# Altium schematic sheet Cheap_FOCer.SchDoc (continued)

## Library data of the parts
C1 C28 = EEU-FC1C470: CAP, AL, 47 µF, 16 V, +/- 20%, 0.8 ohm, AEC-Q200 Grade 2, TH; Manufacturer: Panasonic; AEC Category: Q200; AEC Grade: 2; AEC Qualified: Y; Cap_Case: 5x11mm; Cap_F: 0.000047; CompType: Capacitor; ESR_ohm: 0.8; Green_Lifecycle: Active; Green_REACh: Passed; IRMS_A: 0.175; SizeArea_mm2: 25; SizeAreaWB_mm2: 49; SizeX_mm: 5; SizeZ_mm: 12; TaMax_C: 105; TaMin_C: -55; Tolerance_%: 20; U/M: EA; Value: 47uF; Value1: 0.8 ohm; Value2: 16V; VDC_V: 16; Component Kind: Standard; ComponentLink1Description: Datasheet link; ComponentLink1URL: http://industrial.panasonic.com/www-data/pdf/ABA0000/ABA0000CE22.pdf; Green_EU RoHS: Passed; Green_EU RoHS-2: Incomplete; LatestRevisionDate: 2017-06-22; Library Name: Capacitor_Aluminum.IntLib; MountType: TH; PackageReference: D5xL11mm; PartNumber: EEU-FC1C470; Polarized: Y; RoHS: Y; Series: FC; Signal Integrity: Cap; Simulation: Cap; SizeY_mm: 5; Supplier 1: Digi-Key; Supplier 2: Newark; Supplier 3: Mouser; Supplier Part Number 1: P11196-ND; Supplier Part Number 2: 17T5082; Supplier Part Number 3: 667-EEU-FC1C470; SymbolVersion: 2008-07-09; Technology: AL; Publisher: Texas Instruments
C2 = EMK212B7225KG-T: CAP, CERM, 2.2 µF, 16 V,+/- 10%, X7R, 0805; Manufacturer: Taiyo Yuden; AEC Category: Q200; Cap_Case: 0805; Cap_F: 0.0000022; CompType: Capacitor; Green_Lifecycle: Active; Green_REACh: Passed; Polarized: N; SizeArea_mm2: 2.5; SizeAreaWB_mm2: 6.75; SizeX_mm: 2; SizeY_mm: 1.25; SizeZ_mm: 1.45; TaMax_C: 125; TaMin_C: -55; Tolerance_%: 10; U/M: EA; Value: 2.2uF; VDC_V: 16; AEC Qualified: ?; Component Kind: Standard; ComponentLink1Description: Datasheet link; ComponentLink1URL: http://www.yuden.co.jp/ut/product/pdf/djmk_e.pdf; Green_EU RoHS: Passed; Green_EU RoHS-2: Passed; LatestRevisionDate: 2017-06-22; Library Name: Capacitor_Ceramic.IntLib; MountType: SMT; PackageReference: 0805; PartNumber: EMK212B7225KG-T; Published: 2008-06-19; RoHS: Y; Signal Integrity: Cap; Simulation: Cap; Supplier 1: Digi-Key; Supplier 2: Farnell; Supplier Part Number 1: 587-1431-1-ND; Supplier Part Number 2: 1683654; SymbolVersion: 2008-07-09; Technology: Ceramic; TempCo: X7R; Publisher: Texas Instruments
C3-C6 = GRM219R71E473KA01D: CAP, CERM, 0.047 µF, 25 V,+/- 10%, X7R, 0805; Manufacturer: MuRata; AEC Category: Q200; Cap_Case: 0805; Cap_F: 0.000000047; CompType: Capacitor; Polarized: N; SizeArea_mm2: 2.5; SizeAreaWB_mm2: 6.75; SizeX_mm: 2; SizeY_mm: 1.25; SizeZ_mm: 1.45; TaMax_C: 125; TaMin_C: -55; Tolerance_%: 10; U/M: EA; Value: 0.047uF; Value2: 25V; VDC_V: 25; AEC Qualified: ?; Component Kind: Standard; ComponentLink1Description: Datasheet link; ComponentLink1URL: http://search.murata.co.jp/Ceramy/PnsearchViewAction.do?HnOFG=ON&HnNFG=ON&SEL_CNT=50&sLang=en&sKey=GRM219R71E473KA01D&x=9&y=9&search=startwith; LatestRevisionDate: 2017-06-22; Library Name: Capacitor_Ceramic.IntLib; MountType: SMT; PackageReference: 0805; PartNumber: GRM219R71E473KA01D; Published: 2008-06-19; RoHS: Y; Signal Integrity: Cap; Simulation: Cap; Supplier 1: Mouser; Supplier Part Number 1: 81-GRM40X473K25D; SymbolVersion: 2008-07-09; Technology: Ceramic; TempCo: X7R; Publisher: Texas Instruments
C7 C12 C15 C21 C25 = C0805C104K4RACTU: CAP, CERM, 0.1 µF, 16 V,+/- 10%, X7R, 0805; Manufacturer: Kemet; AEC Category: Q200; Cap_Case: 0805; Cap_F: 0.0000001; CompType: Capacitor; ESR_ohm: 0.064; Green_Lifecycle: Active; Green_REACh: Passed; IRMS_A: 1.64; Polarized: N; SizeArea_mm2: 2.5; SizeAreaWB_mm2: 6.75; SizeX_mm: 2; SizeY_mm: 1.25; SizeZ_mm: 1.45; TaMax_C: 125; TaMin_C: -55; Tolerance_%: 10; U/M: EA; Value: 0.1uF; Value1: 0.064 ohm; Value2: 16V; VDC_V: 16; AEC Qualified: ?; Component Kind: Standard; ComponentLink1Description: Datasheet link; ComponentLink1URL: http://www.kemet.com/kemet/web/homepage/kechome.nsf/file/Partial%20Copy%20-%20Ceramic%20Surface%20Mount%20Capacitors/$file/F3102_CE.pdf; Green_EU RoHS: Passed; Green_EU RoHS-2: Passed; LatestRevisionDate: 2017-06-22; Library Name: Capacitor_Ceramic.IntLib; MountType: SMT; PackageReference: 0805; PartNumber: C0805C104K4RACTU; Published: 2008-06-19; RoHS: Y; Signal Integrity: Cap; Simulation: Cap; Supplier 1: Digi-Key; Supplier 2: Mouser; Supplier 3: Farnell; Supplier Part Number 1: 399-1167-1-ND; Supplier Part Number 2: 80-C0805C104K4R; Supplier Part Number 3: 1414664; SymbolVersion: 2008-07-09; Technology: Ceramic; TempCo: X7R; Publisher: Texas Instruments
C8 C39 C41 C42 = EKMG500ELL4R7ME11D: CAP, AL, 4.7 µF, 50 V, +/- 20%, TH; Manufacturer: Chemi-Con; AEC Category: Q200; AEC Qualified: N; Cap_Case: 5x11mm; Cap_F: 0.0000047; CompType: Capacitor; IRMS_A: 0.03; Polarized: Y; SizeArea_mm2: 25; SizeAreaWB_mm2: 49; SizeX_mm: 5; SizeZ_mm: 12.5; TaMax_C: 105; TaMin_C: -55; Tolerance_%: 20; U/M: EA; Value: 4.7uF; AEC Grade: N/A; Component Kind: Standard; ComponentLink1Description: Datasheet link; ComponentLink1URL: http://www.chemi-con.co.jp/cgi-bin/CAT_DB/SEARCH/cat_db_al.cgi?e=e&j=p&pdfname=kmg; LatestRevisionDate: 2017-06-22; Library Name: Capacitor_Aluminum.IntLib; MountType: TH; PackageReference: D5xL11mm; PartNumber: EKMG500ELL4R7ME11D; RoHS: Y; Series: KMG; Signal Integrity: Cap; Simulation: Cap; SizeY_mm: 5; Supplier 1: Digi-Key; Supplier Part Number 1: 565-1335-ND; SymbolVersion: 2008-07-09; Technology: AL; Publisher: Texas Instruments
C9 = EKMG500ELL4R7ME11D: CAP, AL, 4.7 µF, 50 V, +/- 20%, TH; Manufacturer: Chemi-Con; AEC Category: Q200; AEC Qualified: N; Cap_Case: 5x11mm; Cap_F: 0.0000047; CompType: Capacitor; IRMS_A: 0.03; Polarized: Y; SizeArea_mm2: 25; SizeAreaWB_mm2: 49; SizeX_mm: 5; SizeZ_mm: 12.5; TaMax_C: 105; TaMin_C: -55; Tolerance_%: 20; U/M: EA; Value: 4.7uF; VDC_V: 50; AEC Grade: N/A; Component Kind: Standard; ComponentLink1Description: Datasheet link; ComponentLink1URL: http://www.chemi-con.co.jp/cgi-bin/CAT_DB/SEARCH/cat_db_al.cgi?e=e&j=p&pdfname=kmg; LatestRevisionDate: 2017-06-22; Library Name: Capacitor_Aluminum.IntLib; MountType: TH; PackageReference: D5xL11mm; PartNumber: EKMG500ELL4R7ME11D; RoHS: Y; Series: KMG; Signal Integrity: Cap; Simulation: Cap; SizeY_mm: 5; Supplier 1: Digi-Key; Supplier Part Number 1: 565-1335-ND; SymbolVersion: 2008-07-09; Technology: AL; Publisher: Texas Instruments
C10 C11 C14 C22 C26 C27 C31-C34 C36 C38 C40 C46 = EMK212B7225KG-T: CAP, CERM, 2.2 µF, 16 V,+/- 10%, X7R, 0805; Manufacturer: Taiyo Yuden; AEC Category: Q200; Cap_Case: 0805; Cap_F: 0.0000022; CompType: Capacitor; Green_Lifecycle: Active; Green_REACh: Passed; Polarized: N; SizeArea_mm2: 2.5; SizeAreaWB_mm2: 6.75; SizeX_mm: 2; SizeY_mm: 1.25; SizeZ_mm: 1.45; TaMax_C: 125; TaMin_C: -55; Tolerance_%: 10; U/M: EA; Value: 2.2uF; Value2: 16V; VDC_V: 16; AEC Qualified: ?; Component Kind: Standard; ComponentLink1Description: Datasheet link; ComponentLink1URL: http://www.yuden.co.jp/ut/product/pdf/djmk_e.pdf; Green_EU RoHS: Passed; Green_EU RoHS-2: Passed; LatestRevisionDate: 2017-06-22; Library Name: Capacitor_Ceramic.IntLib; MountType: SMT; PackageReference: 0805; PartNumber: EMK212B7225KG-T; Published: 2008-06-19; RoHS: Y; Signal Integrity: Cap; Simulation: Cap; Supplier 1: Digi-Key; Supplier 2: Farnell; Supplier Part Number 1: 587-1431-1-ND; Supplier Part Number 2: 1683654; SymbolVersion: 2008-07-09; Technology: Ceramic; TempCo: X7R; Publisher: Texas Instruments
C13 = 08055C223KAT2A: CAP, CERM, 0.022 µF, 50 V,+/- 10%, X7R, 0805; Manufacturer: AVX; AEC Category: Q200; AEC Qualified: N; Cap_Case: 0805; Cap_F: 0.000000022; CompType: Capacitor; ESR_ohm: 0.052; Green_Lifecycle: Not Set; Green_REACh: Incomplete; SizeArea_mm2: 2.5; SizeAreaWB_mm2: 6.75; SizeX_mm: 2; SizeY_mm: 1.25; SizeZ_mm: 1.45; TaMax_C: 125; TaMin_C: -55; Tolerance_%: 10; U/M: EA; Value: 0.022uF; Value1: 0.052 ohm; Value2: 50V; VDC_V: 50; AEC Grade: N/A; Component Kind: Standard; ComponentLink1Description: Datasheet link; ComponentLink1URL: http://datasheets.avx.com/X7RDielectric.pdf; Green_EU RoHS: Incomplete; Green_EU RoHS-2: Incomplete; LatestRevisionDate: 2017-06-22; Library Name: Capacitor_Ceramic.IntLib; MountType: SMT; PackageReference: 0805; PartNumber: 08055C223KAT2A; Polarized: N; Published: 2008-06-19; RoHS: Y; Signal Integrity: Cap; Simulation: Cap; Supplier 1: Digi-Key; Supplier 2: Newark; Supplier 3: Mouser; Supplier Part Number 1: 478-1387-1-ND; Supplier Part Number 2: 35K2132; Supplier Part Number 3: 581-08055C223K; SymbolVersion: 2008-07-09; Technology: Ceramic; TempCo: X7R; Publisher: Texas Instruments
C16 C18 C20 = GRM21BR71H224KA01L: CAP, CERM, 0.22 µF, 50 V,+/- 10%, X7R, 0805; Manufacturer: MuRata; AEC Category: Q200; Cap_Case: 0805; Cap_F: 0.00000022; CompType: Capacitor; Green_Lifecycle: Active; Green_REACh: Passed; Polarized: N; SizeArea_mm2: 2.5; SizeAreaWB_mm2: 6.75; SizeX_mm: 2; SizeY_mm: 1.25; SizeZ_mm: 1.45; TaMax_C: 125; TaMin_C: -55; Tolerance_%: 10; U/M: EA; Value: 0.22uF; Value2: 50V; VDC_V: 50; AEC Qualified: ?; Component Kind: Standard; ComponentLink1Description: Datasheet link; ComponentLink1URL: http://search.murata.co.jp/Ceramy/PnsearchViewAction.do?HnOFG=ON&HnNFG=ON&SEL_CNT=50&sLang=en&sKey=GRM21BR71H224KA01L&x=9&y=9&search=startwith; Green_EU RoHS: Passed; Green_EU RoHS-2: Incomplete; LatestRevisionDate: 2017-06-22; Library Name: Capacitor_Ceramic.IntLib; MountType: SMT; PackageReference: 0805; PartNumber: GRM21BR71H224KA01L; Published: 2008-06-19; RoHS: Y; Signal Integrity: Cap; Simulation: Cap; Supplier 1: Digi-Key; Supplier 2: Mouser; Supplier 3: Farnell; Supplier Part Number 1: 490-1670-1-ND; Supplier Part Number 2: 81-GRM40X7R224K050AL; Supplier Part Number 3: 8820139; SymbolVersion: 2008-07-09; Technology: Ceramic; TempCo: X7R; Publisher: Texas Instruments
C17 C19 = C0805C150J5GACTU: CAP, CERM, 15 pF, 50 V,+/- 5%, C0G/NP0, 0805; Manufacturer: Kemet; AEC Category: Q200; Cap_Case: 0805; Cap_F: 0.000000000015; CompType: Capacitor; ESR_ohm: 0.217; Green_Lifecycle: Not Set; Green_REACh: Incomplete; IRMS_A: 0.331; Polarized: N; SizeArea_mm2: 2.5; SizeAreaWB_mm2: 6.75; SizeX_mm: 2; SizeY_mm: 1.25; SizeZ_mm: 1.45; TaMax_C: 125; TaMin_C: -55; Tolerance_%: 5; U/M: EA; Value1: 0.217 ohm; Value2: 50V; VDC_V: 50; AEC Qualified: ?; Component Kind: Standard; ComponentLink1Description: Datasheet link; ComponentLink1URL: http://www.kemet.com/kemet/web/homepage/kechome.nsf/file/Partial%20Copy%20-%20Ceramic%20Surface%20Mount%20Capacitors/$file/F3102_CE.pdf; Green_EU RoHS: Incomplete; Green_EU RoHS-2: Incomplete; LatestRevisionDate: 2017-06-22; Library Name: Capacitor_Ceramic.IntLib; MountType: SMT; PackageReference: 0805; PartNumber: C0805C150J5GACTU; Published: 2008-06-19; RoHS: Y; Signal Integrity: Cap; Simulation: Cap; Supplier 1: Digi-Key; Supplier 2: Newark; Supplier 3: Mouser; Supplier Part Number 1: 399-1111-1-ND; Supplier Part Number 2: 64K2902; Supplier Part Number 3: 80-C0805C150J5G; SymbolVersion: 2008-07-09; Technology: Ceramic; TempCo: C0G/NP0; ValueDisplayed: 15pF; Publisher: Texas Instruments
C23 C37 = B41866C6567M: CAP, AL, 560 µF, 50 V, +/- 20%, 0.05 ohm, AEC-Q200 Grade 1, TH; Manufacturer: TDK; AEC Category: Q200; AEC Grade: 1; AEC Qualified: Y; Cap_Case: 16x20mm; Cap_F: 0.00056; CompType: Capacitor; ESR_ohm: 0.05; IRMS_A: 1.895; SizeArea_mm2: 256; SizeAreaWB_mm2: 324; SizeX_mm: 16; SizeZ_mm: 22; TaMax_C: 125; TaMin_C: -55; Tolerance_%: 20; U/M: EA; Value: 560uF; Value2: 50V; VDC_V: 50; Component Kind: Standard; ComponentLink1Description: Datasheet link; ComponentLink1URL: http://en.tdk.eu/inf/20/30/db/aec_2015/B41866.pdf; LatestRevisionDate: 2017-06-22; Library Name: Capacitor_Aluminum.IntLib; MountType: TH; PackageReference: D16xL20mm; PartNumber: B41866C6567M; Polarized: Y; RoHS: Y; Series: B14866; Signal Integrity: Cap; Simulation: Cap; SizeY_mm: 16; SymbolVersion: 2008-07-09; Technology: AL; Value1: 0.05 ohm; Publisher: Texas Instruments
C24 C29 = 08055A222JAT2A: CAP, CERM, 2200 pF, 50 V,+/- 5%, C0G/NP0, 0805; Manufacturer: AVX; AEC Category: Q200; AEC Qualified: N; Cap_Case: 0805; Cap_F: 0.0000000022; CompType: Capacitor; ESR_ohm: 0.08; Green_Lifecycle: Not Set; Green_REACh: Incomplete; SizeArea_mm2: 2.5; SizeAreaWB_mm2: 6.75; SizeX_mm: 2; SizeY_mm: 1.25; SizeZ_mm: 1.45; TaMax_C: 125; TaMin_C: -55; Tolerance_%: 5; U/M: EA; Value1: 0.08 ohm; Value2: 50V; VDC_V: 50; AEC Grade: N/A; Component Kind: Standard; ComponentLink1Description: Datasheet link; ComponentLink1URL: http://datasheets.avx.com/C0GNP0-Dielectric.pdf; Green_EU RoHS: Incomplete; Green_EU RoHS-2: Incomplete; LatestRevisionDate: 2017-06-22; Library Name: Capacitor_Ceramic.IntLib; MountType: SMT; PackageReference: 0805; PartNumber: 08055A222JAT2A; Polarized: N; Published: 2008-06-19; RoHS: Y; Signal Integrity: Cap; Simulation: Cap; Supplier 1: Digi-Key; Supplier 2: Newark; Supplier 3: Mouser; Supplier Part Number 1: 478-1330-1-ND; Supplier Part Number 2: 32H4914; Supplier Part Number 3: 581-08055A222J; SymbolVersion: 2008-07-09; Technology: Ceramic; TempCo: C0G/NP0; ValueDisplayed: 2200pF; Publisher: Texas Instruments
C30 = GRM2195C1H682FA01D: CAP, CERM, 6800 pF, 50 V,+/- 1%, C0G/NP0, 0805; Manufacturer: MuRata; AEC Category: Q200; AEC Qualified: N; Cap_Case: 0805; Cap_F: 0.0000000068; CompType: Capacitor; SizeArea_mm2: 2.5; SizeAreaWB_mm2: 6.75; SizeX_mm: 2; SizeY_mm: 1.25; SizeZ_mm: 1.45; TaMax_C: 125; TaMin_C: -55; Tolerance_%: 1; U/M: EA; Value2: 50V; VDC_V: 50; AEC Grade: N/A; Component Kind: Standard; ComponentLink1Description: Datasheet link; ComponentLink1URL: http://www.murata.com/~/media/webrenewal/support/library/catalog/products/capacitor/mlcc/c02e.pdf; LatestRevisionDate: 2017-06-22; Library Name: Capacitor_Ceramic.IntLib; MountType: SMT; PackageReference: 0805; PartNumber: GRM2195C1H682FA01D; Polarized: N; Published: 2008-06-19; RoHS: Y; Signal Integrity: Cap; Simulation: Cap; Supplier 1: Digi-Key; Supplier 2: Mouser; Supplier Part Number 1: 490-10742-1-ND; Supplier Part Number 2: 81-GRM2195C1H682FA1D; SymbolVersion: 2008-07-09; Technology: Ceramic; TempCo: C0G/NP0; ValueDisplayed: 6800pF; Publisher: Texas Instruments
C35 = 08055A121JAT2A: CAP, CERM, 120 pF, 50 V,+/- 5%, C0G/NP0, 0805; Manufacturer: AVX; AEC Category: Q200; AEC Qualified: N; Cap_Case: 0805; Cap_F: 0.00000000012; CompType: Capacitor; ESR_ohm: 0.319; Green_Lifecycle: Not Set; Green_REACh: Incomplete; SizeArea_mm2: 2.5; SizeAreaWB_mm2: 6.75; SizeX_mm: 2; SizeY_mm: 1.25; SizeZ_mm: 1.45; TaMax_C: 125; TaMin_C: -55; Tolerance_%: 5; U/M: EA; Value1: 0.319 ohm; Value2: 50V; VDC_V: 50; AEC Grade: N/A; Component Kind: Standard; ComponentLink1Description: Datasheet link; ComponentLink1URL: http://datasheets.avx.com/C0GNP0-Dielectric.pdf; Green_EU RoHS: Incomplete; Green_EU RoHS-2: Incomplete; LatestRevisionDate: 2017-06-22; Library Name: Capacitor_Ceramic.IntLib; MountType: SMT; PackageReference: 0805; PartNumber: 08055A121JAT2A; Polarized: N; Published: 2008-06-19; RoHS: Y; Signal Integrity: Cap; Simulation: Cap; Supplier 1: Digi-Key; Supplier 2: Mouser; Supplier 3: Farnell; Supplier Part Number 1: 478-1317-1-ND; Supplier Part Number 2: 581-08055A121J; Supplier Part Number 3: 1395923; SymbolVersion: 2008-07-09; Technology: Ceramic; TempCo: C0G/NP0; ValueDisplayed: 120pF; Publisher: Texas Instruments
C43-C45 = CMP-0079493-1: CAP, Film, 0.1 µF, 100 V,+/- 2.5%, TH; Manufacturer: WIMA; AEC Category: Q200; AEC Qualified: N; Cap_Case: 7.2x4.5x6mm; Cap_F: 100nF; CompType: Capacitor; SizeArea_mm2: 32.4; SizeAreaWB_mm2: 59.8; SizeX_mm: 7.2; SizeY_mm: 4.5; SizeZ_mm: 6; TaMax_C: 100°C; TaMin_C: -55°C; Value: 0.1uF; AEC Grade: N/A; Component Kind: Standard; ComponentLink1Description: Datasheet link; ComponentLink1URL: https://www.wima.de/wp-content/uploads/media/d_WIMA_FKP_2.pdf; Library Name: Altium Vault - TI; MountType: TH; PackageReference: 7.2x4.5mm; PartNumber: FKP2D001001D00HSSD; Polarized: N; RoHS: Y; Series: ?; Supplier 1: Mouser; Supplier Part Number 1: 505-FKP2100/100/2.5; Technology: Film; Tolerance_%: 2.5%; VDC_V: 100V
D1 D4 = 150080SS75000: LED, Red, SMD; Manufacturer: Wurth Elektronik; Angle: 140; Green_Lifecycle: Active; Green_REACh: Passed; Io_A: 0.03; Ir_A: 2.5e-005; Power_TotalModule: 0.072; Tjmax_C: 85; Type: AlInGaP; U/M: EA; Value1: 0.072W; Value2: 1.9V; Vf_V: 1.9; Vr_V: 5; Component Kind: Standard; ComponentLink1Description: Datasheet link; ComponentLink1URL: http://katalog.we-online.de/led/datasheet/150080SS75000.pdf; CompType: LED; Green_EU RoHS: Passed; Green_EU RoHS-2: Incomplete; LatestRevisionDate: 2017-06-23; Library Name: LED.IntLib; MountType: SMT; PackageReference: LED_0805; PartNumber: 150080SS75000; Published: 2008-07-11; RoHS: Y; Signal Integrity: Diode; Supplier 1: Digi-Key; Supplier 2: Newark; Supplier 3: Mouser; Supplier Part Number 1: 732-4985-1-ND; Supplier Part Number 2: 95W3802; Supplier Part Number 3: 710-150080SS75000; SymbolVersion: 2008-11-06; Publisher: Texas Instruments
  D4: Color: Red
D2 = 150080GS75000: LED, Green, SMD; Manufacturer: Wurth Elektronik; Angle: 140; Io_A: 0.02; Ir_A: 1e-005; Power_TotalModule: 0.105; Tjmax_C: 85; Type: InGaN; U/M: EA; Value1: 0.105W; Value2: 3.2V; Vf_V: 3.2; Vr_V: 5; Component Kind: Standard; ComponentLink1Description: Datasheet link; ComponentLink1URL: http://katalog.we-online.de/led/datasheet/150080GS75000.pdf; CompType: LED; LatestRevisionDate: 2017-06-23; Library Name: LED.IntLib; MountType: SMT; PackageReference: LED_0805; PartNumber: 150080GS75000; Published: 2008-07-11; RoHS: Y; Signal Integrity: Diode; Supplier 1: Digi-Key; Supplier 2: Newark; Supplier 3: Mouser; Supplier Part Number 1: 732-4983-1-ND; Supplier Part Number 2: 95W3800; Supplier Part Number 3: 710-150080GS75000; SymbolVersion: 2008-11-06; Publisher: Texas Instruments
D3 = B260-13-F: Diode, Schottky, 60 V, 2 A, SMB; Manufacturer: Diodes Inc.; AEC Category: Q101; Green_Lifecycle: Active; Green_REACh: Passed; Io_A: 2; RJC_CperW: 20; SizeArea_mm2: 21.336; SizeAreaWB_mm2: 44.156; SizeX_mm: 5.6; SizeY_mm: 3.81; SizeZ_mm: 2.6; TJmax_C: 150; U/M: EA; Value: 60V; Value1: 2A; Value2: 0.7V; Vf_V: 0.7; Vr_V: 60; AEC Qualified: ?; Component Kind: Standard; ComponentLink1Description: Datasheet link; ComponentLink1URL: http://www.diodes.com/datasheets/ds13004.pdf; CompType: Diode; Green_EU RoHS: Passed with Exemption; Green_EU RoHS-2: Passed; LatestRevisionDate: 2017-06-26; Library Name: Diode.IntLib; MountType: SMT; PackageReference: SMB; PartNumber: B260-13-F; Published: 2008-06-26; RoHS: Y; Signal Integrity: Diode; Simulation: DI_B260; Supplier 1: Digi-Key; Supplier 2: Mouser; Supplier 3: Farnell; Supplier Part Number 1: B260-FDICT-ND; Supplier Part Number 2: 621-B260-F; Supplier Part Number 3: 1858612; SymbolVersion: 2008-12-08; Type: Schottky; Publisher: Texas Instruments
D5 = CMP-0084939-1: Diode, TVS, Uni, 5 V, 9.2 Vc, 400 W, 43.5 A, SMA; Manufacturer: Littelfuse; PartNumber: SMAJ5.0A; AEC Category: Q101; AEC Qualified: N; Ipp: 43.5A; Ppk: 400W; RJA_CperW: 120; SizeArea_mm2: 16.3228; SizeAreaWB_mm2: 37.3428; SizeX_mm: 5.59; SizeY_mm: 2.92; SizeZ_mm: 2.87; Value1: 9.2V; Vbr_Max: 7V; Vbr_Min: 6.4V; Component Kind: Standard; ComponentLink1Description: Datasheet link; ComponentLink1URL: https://www.littelfuse.com/~/media/electronics/datasheets/tvs_diodes/littelfuse_tvs_diode_smaj_datasheet.pdf.pdf; Library Name: Altium Vault - TI; MountType: SMT; PackageReference: SMA; RoHS: Y; Vc: 9.2V; VRWM: 5V
J1 = CMP-0054926-1: Connector, Receptacle, USB Mini B 2.0, 5 Position, TH; Manufacturer: TE Connectivity; CNHeader: FALSE; CT: TRUE; Gender: Female; Pitch_mm: 0.8; Component Kind: Standard; ComponentLink1Description: Datasheet URL; ComponentLink1URL: http://www.te.com/commerce/DocumentDelivery/DDEController?Action=srchrtrv&DocNm=1734753&DocType=Customer+Drawing&DocLang=English; CompType: USB; LatestRevisionDate: 26/05/2017; Library Name: Altium Vault - TI; MountType: TH; Orientation: Vertical; PackageReference: Connector, Receptacle, USB Mini B 2.0, 5 Position, TH; PartNumber: 1734753-1; PinCount: 5; RoHS: Y; Supplier 1: Digi-Key; Supplier 2: Newark; Supplier 3: Mouser; Supplier Part Number 1: A107790-ND; Supplier Part Number 2: 16P3528; Supplier Part Number 3: 571-1734753-1; Publisher: Texas Instruments
J2 = 800-10-003-10-001000: Header, 100mil, 3x1, TH; Manufacturer: Mill-Max; Green_Lifecycle: Active; Green_REACh: Passed; SymbolCreator: a0799628; ClassName: Header; Component Kind: Standard; ComponentLink1Description: Datasheet URL; ComponentLink1URL: http://www.mill-max.com/assets/pdfs/054.PDF; CompType: Header; Green_EU RoHS: Passed with Exemption; Green_EU RoHS-2: Incomplete; LatestRevisionDate: 2017-06-03; Library Name: Connectors_Mill_Max.IntLib; MountType: TH; PackageReference: Header, 3x1, 100mil, TH; PartNumber: 800-10-003-10-001000; RoHS: Y; Supplier 1: Digi-Key; Supplier Part Number 1: ED7203-ND; SymbolVersion: 2013-03-28; Publisher: Texas Instruments
J3 = 800-10-004-10-001000: Header, 100mil, 4x1, TH; Manufacturer: Mill-Max; SymbolCreator: a0412235; ClassName: Header; Component Kind: Standard; ComponentLink1Description: Datasheet URL; ComponentLink1URL: http://www.mill-max.com/assets/pdfs/054.PDF; CompType: Header; LatestRevisionDate: 2017-06-03; Library Name: Connectors_Mill_Max.IntLib; MountType: TH; PackageReference: Header, 4x1, 100mil, TH; PartNumber: 800-10-004-10-001000; RoHS: Y; Supplier 1: Digi-Key; Supplier Part Number 1: ED7204-ND; SymbolVersion: 2013-08-30; Publisher: Texas Instruments
J4 J5 = 800-10-006-10-001000: Header, 100mil, 6x1, TH; Manufacturer: Mill-Max; SymbolCreator: a0412235; ClassName: Header; Component Kind: Standard; ComponentLink1Description: Datasheet URL; ComponentLink1URL: http://www.mill-max.com/assets/pdfs/054.PDF; CompType: Header; LatestRevisionDate: 2017-06-03; Library Name: Connectors_Mill_Max.IntLib; MountType: TH; PackageReference: Header, 6x1, 100mil, TH; PartNumber: 800-10-006-10-001000; RoHS: Y; Supplier 1: Digi-Key; Supplier Part Number 1: ED7206-ND; SymbolVersion: 2013-08-30; Publisher: Texas Instruments
J6 = 800-10-007-10-001000: Header, 100mil, 7x1, TH; Manufacturer: Mill-Max; SymbolCreator: a0411947; ClassName: Header; Component Kind: Standard; ComponentLink1Description: Datasheet URL; ComponentLink1URL: http://www.mill-max.com/assets/pdfs/054.PDF; CompType: Header; LatestRevisionDate: 2017-06-03; Library Name: Connectors_Mill_Max.IntLib; MountType: TH; PackageReference: Header, 7x1, 100mil, TH; PartNumber: 800-10-007-10-001000; RoHS: Y; Supplier 1: Digi-Key; Supplier Part Number 1: ED7207-ND; SymbolVersion: 2013-12-22; Publisher: Texas Instruments
J7 = 0022284023: Header, 2.54mm, 2x1, Tin, TH; Manufacturer: Molex; Cert_UL: Y; Current_A: 4; Gender: Male; Pitch_mm: 2.54; Row_Count: 1; Shrouded: N; SymbolCreator: a0412235; Voltage_V: 250; ClassName: Header; Color: Black; Component Kind: Standard; ComponentLink1Description: Datasheet URL; ComponentLink1URL: http://www.molex.com/pdm_docs/sd/022284023_sd.pdf; CompType: Header; ContactFinish: Tin; LatestRevisionDate: 2017-06-22; Library Name: Connectors_Molex.IntLib; MountType: TH; Orientation: Vertical; PackageReference: Header, 2.54mm, 2x1, TH; PartNumber: 0022284023; PinCount: 2; RoHS: Y; Supplier 1: Digi-Key; Supplier Part Number 1: WM50016-02-ND; SymbolVersion: 2013-10-31; Publisher: Texas Instruments
L1 = SRP6540-220M: Inductor, Shielded, Ferrite, 22 µH, 2.5 A, 0.133 ohm, SMD; Manufacturer: Bourns; AEC Category: Q200; DCR_ohm: 0.133; Green_Lifecycle: Active; Green_REACh: Passed; Imax_A: 2.5; Ind_H: 22.0E-6; Irms: 2.5A @ 40C rise; Isat: 2.5A @ 20% inductance drop; SizeArea_mm2: 50.25; SizeAreaWB_mm2: 82.65; SizeX_mm: 7.5; SizeY_mm: 6.7; SizeZ_mm: 4; Value: 22uH; Value2: 2.5A; AEC Qualified: ?; Component Kind: Standard; ComponentLink1Description: Datasheet link; ComponentLink1URL: http://www.bourns.com/data/global/pdfs/SRP6540.pdf; CompType: Inductor; Green_EU RoHS: Passed; Green_EU RoHS-2: Incomplete; LatestRevisionDate: 2017-06-26; Library Name: Inductor_Bourns.IntLib; Material: Ferrite; MountType: SMT; PackageReference: Inductor, 7.2x4x6.5mm; PartNumber: SRP6540-220M; Published: 2008-06-26; RoHS: Y; Signal Integrity: Inductor; Simulation: Inductor; Supplier 1: Mouser; Supplier Part Number 1: 652-SRP6540-220M; SymbolVersion: 2008-07-23; Technology: Shielded; Value1: 0.133 ohm; Publisher: Texas Instruments
Q1-Q6 = IPP023NE7N3 G: MOSFET, N-CH, 75 V, 120 A, TO-220AB; Alternate Manufacturer: None; Manufacturer: Infineon Technologies; AEC Category: Q101; ChType: N; Green_Lifecycle: Not Set; Green_REACh: Incomplete; IdsMax_A: 120; QgTyp_nC: 155; Rdson45_ohm: 0.2; SizeArea_mm2: 45.9; SizeAreaWB_mm2: 79.3; SizeX_mm: 10.2; SizeY_mm: 4.5; SizeZ_mm: 19.4; U/M: EA; Value: 75V; Value1: 0.2 ohm; Value2: 155 nC; VdsMax_V: 75; Vgs_V: 20; AEC Qualified: ?; Component Kind: Standard; ComponentLink1Description: Datasheet link; ComponentLink1URL: http://www.infineon.com/dgdl/IPP_I023NE7N3_Rev2+11.pdf?folderId=db3a304313b8b5a60113cee8763b02d7&fileId=db3a304320d39d5901210a9da8c22702; CompType: MOSFET; Green_EU RoHS: Incomplete; Green_EU RoHS-2: Incomplete; LatestRevisionDate: 2017-06-26; LatestRevisionNote: Added parts.; Library Name: FETs.IntLib; MountType: TH; PackageReference: TO-220AB; PartNumber: IPP023NE7N3 G; Published: 2008-06-19; RoHS: Y; Supplier 1: Digi-Key; Supplier Part Number 1: IPP023NE7N3 GCT-ND; SymbolVersion: 2008-07-28; Publisher: Texas Instruments
R1 R5-R7 R18 R25 R30 R31 R34 = CRCW08052K20JNEA: RES, 2.2 k, 5%, 0.125 W, 0805; Manufacturer: Vishay-Dale; AEC Category: Q200; CompType: Resistor; EIA_Code: E24; Power_W: 0.125; Res_ohm: 2200; SizeArea_mm2: 2.5; SizeX_mm: 2; SizeY_mm: 1.25; SizeZ_mm: 1.45; TempCo: 200 ppm/C; Tolerance_%: 5; U/M: EA; Value2: 0.125W; Value_Code: 2k20; VDC_V: 150; AEC Qualified: ?; Component Kind: Standard; ComponentLink1Description: Datasheet link; ComponentLink1URL: http://www.vishay.com/docs/20035/dcrcwe3.pdf; LatestRevisionDate: 2017-06-23; Library Name: Resistor_0805.IntLib; MountType: SMT; PackageReference: 0805; PartNumber: CRCW08052K20JNEA; Published: 2008-06-26; RoHS: Y; Signal Integrity: Resistor; Simulation: Resistor; Supplier 1: Digi-Key; Supplier 2: Newark; Supplier 3: Mouser; Supplier Part Number 1: 541-2.2KACT-ND; Supplier Part Number 2: 59M6865; Supplier Part Number 3: 71-CRCW08052K20JNEA; SymbolVersion: 2009-05-29; Technology: Thick Film; Value1: 5%; ValueDisplayed: 2.2k; Publisher: Texas Instruments
R2 = RC0805FR-0718KL: RES, 18.0 k, 1%, 0.125 W, 0805; Manufacturer: Yageo America; AEC Category: Q200; AEC Qualified: N; CompType: Resistor; Green_Lifecycle: Active; Green_REACh: Passed; Power_W: 0.125; Res_ohm: 18000; SizeArea_mm2: 2.5; SizeX_mm: 2; SizeY_mm: 1.25; SizeZ_mm: 1.45; TempCo: 100 ppm/C; Tolerance_%: 1; U/M: EA; Value2: 0.125W; Value_Code: 18k0; AEC Grade: N/A; Component Kind: Standard; ComponentLink1Description: Datasheet link; ComponentLink1URL: http://www.yageo.com/documents/recent/PYu-RC_Group_51_RoHS_L_7.pdf; Green_EU RoHS: Passed with Exemption; Green_EU RoHS-2: Passed; LatestRevisionDate: 2017-06-23; Library Name: Resistor_0805.IntLib; MountType: SMT; PackageReference: 0805; PartNumber: RC0805FR-0718KL; Published: 2008-06-26; RoHS: Y; Signal Integrity: Resistor; Simulation: Resistor; Supplier 1: Digi-Key; Supplier 2: Newark; Supplier 3: Mouser; Supplier Part Number 1: 311-18.0KCRCT-ND; Supplier Part Number 2: 98K7805; Supplier Part Number 3: 603-RC0805FR-0718KL; SymbolVersion: 2009-05-29; Technology: Thick Film; Value1: 1%; ValueDisplayed: 18.0k; Publisher: Texas Instruments
R3 = RG2012P-332-B-T5: RES, 3.30 k, 0.1%, 0.125 W, 0805; Manufacturer: Susumu Co Ltd; AEC Category: Q200; CompType: Resistor; Power_W: 0.125; Res_ohm: 3300; SizeArea_mm2: 2.5; SizeX_mm: 2; SizeY_mm: 1.25; SizeZ_mm: 1.45; TempCo: 25 ppm/C; Tolerance_%: 0.1; U/M: EA; Value2: 0.125W; Value_Code: 3k30; VDC_V: 100; AEC Qualified: ?; Component Kind: Standard; ComponentLink1Description: Datasheet link; ComponentLink1URL: http://www.susumu.co.jp/english/pdf/products-j01-01.pdf; LatestRevisionDate: 2017-06-23; Library Name: Resistor_0805.IntLib; MountType: SMT; PackageReference: 0805; PartNumber: RG2012P-332-B-T5; Published: 2008-06-26; RoHS: Y; Signal Integrity: Resistor; Simulation: Resistor; Supplier 1: Digi-Key; Supplier 2: Mouser; Supplier Part Number 1: RG20P3.3KBCT-ND; Supplier Part Number 2: 754-RG2012P-332-BT5; SymbolVersion: 2009-05-29; Technology: Thin Film; Value1: 0.1%; ValueDisplayed: 3.30k; Publisher: Texas Instruments
R4 R12 R13 R16 R28 R33 = CRCW080510K0FKEA: RES, 10.0 k, 1%, 0.125 W, 0805; Manufacturer: Vishay-Dale; AEC Category: Q200; CompType: Resistor; EIA_Code: E96; Green_Lifecycle: Active; Green_REACh: Passed; Power_W: 0.125; Res_ohm: 10000; SizeArea_mm2: 2.5; SizeX_mm: 2; SizeY_mm: 1.25; SizeZ_mm: 1.45; TempCo: 100 ppm/C; Tolerance_%: 1; U/M: EA; Value2: 0.125W; Value_Code: 10k0; VDC_V: 150; AEC Qualified: ?; Component Kind: Standard; ComponentLink1Description: Datasheet link; ComponentLink1URL: http://www.vishay.com/docs/20035/dcrcwe3.pdf; Green_EU RoHS: Passed with Exemption; Green_EU RoHS-2: Passed; LatestRevisionDate: 2017-06-23; Library Name: Resistor_0805.IntLib; MountType: SMT; PackageReference: 0805; PartNumber: CRCW080510K0FKEA; Published: 2008-06-26; RoHS: Y; Signal Integrity: Resistor; Simulation: Resistor; Supplier 1: Digi-Key; Supplier 2: Newark; Supplier 3: Mouser; Supplier Part Number 1: 541-10.0KCCT-ND; Supplier Part Number 2: 52K9795; Supplier Part Number 3: 71-CRCW0805-10K-E3; SymbolVersion: 2009-05-29; Technology: Thick Film; Value1: 1%; ValueDisplayed: 10.0k; Publisher: Texas Instruments
R8-R10 R20-R22 R45-R48 = RC1206FR-074R7L: RES, 4.70, 1%, 0.25 W, 1206; Manufacturer: Yageo America; AEC Category: Q200; CompType: Resistor; Power_W: 0.25; Res_ohm: 4.7; SizeArea_mm2: 5.12; SizeX_mm: 3.2; SizeY_mm: 1.6; SizeZ_mm: 1.35; TempCo: 200 ppm/C; Tolerance_%: 1; U/M: EA; Value2: 0.25W; Value_Code: 4R70; VDC_V: 200; AEC Qualified: ?; Component Kind: Standard; ComponentLink1Description: Datasheet link; ComponentLink1URL: http://www.yageo.com/pdf/Pu-RC1206_51_PbFree_L_2.pdf; LatestRevisionDate: 2017-06-12; Library Name: Resistor_1206.IntLib; MountType: SMT; PackageReference: 1206; PartNumber: RC1206FR-074R7L; Published: 2008-06-26; RoHS: Y; Signal Integrity: Resistor; Simulation: Resistor; Supplier 1: Digi-Key; Supplier 2: Mouser; Supplier 3: Farnell; Supplier Part Number 1: 311-4.70FRCT-ND; Supplier Part Number 2: 603-RC1206FR-074R7L; Supplier Part Number 3: 9236600; SymbolVersion: 2009-05-29; Technology: Thick Film; Value1: 1%; ValueDisplayed: 4.70; Publisher: Texas Instruments
R11 R27 = ERJ-M1WTF1M0U: RES, 0.001, 1%, 1 W, 2512; Manufacturer: Panasonic; AEC Category: Q200; CompType: Resistor; EIA_Code: E96; Green_Lifecycle: Not Set; Green_REACh: Incomplete; Power_W: 1; SizeArea_mm2: 20.16; SizeX_mm: 6.3; SizeY_mm: 3.2; SizeZ_mm: 0.71; TempCo: ppm/C; U/M: EA; Value2: 1W; Value_Code: 1L000; AEC Qualified: ?; Component Kind: Standard; ComponentLink1Description: Datasheet link; ComponentLink1URL: http://industrial.panasonic.com/www-cgi/jvcr13pz.cgi?E+PZ+3+AOA0007+ERJM1WTF3M0U+7+WW; Green_EU RoHS: Incomplete; Green_EU RoHS-2: Incomplete; LatestRevisionDate: 2017-06-26; Library Name: Resistor_2512.IntLib; MountType: SMT; PackageReference: 2512; PartNumber: ERJ-M1WTF1M0U; Published: 2008-06-26; RoHS: Y; Signal Integrity: Resistor; Simulation: Resistor; Supplier 1: Digi-Key; Supplier 2: Mouser; Supplier 3: Farnell; Supplier Part Number 1: P1.0NDCT-ND; Supplier Part Number 2: 667-ERJ-M1WTF1M0U; Supplier Part Number 3: 1577574; SymbolVersion: 2009-05-29; Technology: Thick Film; Tolerance_%: 1; Value1: 1%; ValueDisplayed: 0.001; Publisher: Texas Instruments
R14 R15 = CRCW0805200RFKEA: RES, 200, 1%, 0.125 W, 0805; Manufacturer: Vishay-Dale; AEC Category: Q200; CompType: Resistor; EIA_Code: E96; Green_Lifecycle: Active; Green_REACh: Passed; Power_W: 0.125; SizeArea_mm2: 2.5; SizeX_mm: 2; SizeY_mm: 1.25; SizeZ_mm: 1.45; TempCo: 100 ppm/C; Tolerance_%: 1; U/M: EA; Value2: 0.125W; Value_Code: 200R; VDC_V: 150; AEC Qualified: ?; Component Kind: Standard; ComponentLink1Description: Datasheet link; ComponentLink1URL: http://www.vishay.com/docs/20035/dcrcwe3.pdf; Green_EU RoHS: Passed with Exemption; Green_EU RoHS-2: Passed; LatestRevisionDate: 2017-06-23; Library Name: Resistor_0805.IntLib; MountType: SMT; PackageReference: 0805; PartNumber: CRCW0805200RFKEA; Published: 2008-06-26; Res_ohm: 200; RoHS: Y; Signal Integrity: Resistor; Simulation: Resistor; Supplier 1: Digi-Key; Supplier 2: Newark; Supplier 3: Mouser; Supplier Part Number 1: 541-200CCT-ND; Supplier Part Number 2: 53K0006; Supplier Part Number 3: 71-CRCW0805-200-E3; SymbolVersion: 2009-05-29; Technology: Thick Film; Value1: 1%; ValueDisplayed: 200; Publisher: Texas Instruments
R17 R19 R23 R24 R26 R29 R32 = RG2012P-393-B-T5: RES, 39.0 k, 0.1%, 0.125 W, 0805; Manufacturer: Susumu Co Ltd; AEC Category: Q200; CompType: Resistor; Power_W: 0.125; Res_ohm: 39000; SizeArea_mm2: 2.5; SizeX_mm: 2; SizeY_mm: 1.25; SizeZ_mm: 1.45; TempCo: 25 ppm/C; Tolerance_%: 0.1; U/M: EA; Value2: 0.125W; Value_Code: 39k0; VDC_V: 100; AEC Qualified: ?; Component Kind: Standard; ComponentLink1Description: Datasheet link; ComponentLink1URL: http://www.susumu.co.jp/english/pdf/products-j01-01.pdf; LatestRevisionDate: 2017-06-23; Library Name: Resistor_0805.IntLib; MountType: SMT; PackageReference: 0805; PartNumber: RG2012P-393-B-T5; Published: 2008-06-26; RoHS: Y; Signal Integrity: Resistor; Simulation: Resistor; Supplier 1: Digi-Key; Supplier 2: Mouser; Supplier Part Number 1: RG20P39.0KBCT-ND; Supplier Part Number 2: 754-RG2012P-393-BT5; SymbolVersion: 2009-05-29; Technology: Thin Film; Value1: 0.1%; ValueDisplayed: 39.0k; Publisher: Texas Instruments
R35 = ERJ-6ENF1004V: RES, 1.00 M, 1%, 0.125 W, 0805; Manufacturer: Panasonic; AEC Category: Q200; CompType: Resistor; EIA_Code: E96; Power_W: 0.125; Res_ohm: 1000000; SizeArea_mm2: 2.5; SizeX_mm: 2; SizeY_mm: 1.25; SizeZ_mm: 1.45; TempCo: 100 ppm/C; Tolerance_%: 1; U/M: EA; Value: 1.00Meg; Value2: 0.125W; Value_Code: 1M00; AEC Qualified: ?; Component Kind: Standard; ComponentLink1Description: Datasheet link; ComponentLink1URL: http://www.panasonic.com/industrial/components/pdf/AOA0000CE2.pdf; LatestRevisionDate: 2017-06-23; Library Name: Resistor_0805.IntLib; MountType: SMT; PackageReference: 0805; PartNumber: ERJ-6ENF1004V; Published: 2008-06-26; RoHS: Y; Signal Integrity: Resistor; Simulation: Resistor; Supplier 1: Digi-Key; Supplier 2: Mouser; Supplier 3: Farnell; Supplier Part Number 1: P1.00MCCT-ND; Supplier Part Number 2: 667-ERJ-6ENF1004V; Supplier Part Number 3: 1748405; SymbolVersion: 2009-05-29; Technology: Thick Film; Value1: 1%; Publisher: Texas Instruments
R36 R37 = CRCW080522R0JNEA: RES, 22, 5%, 0.125 W, 0805; Manufacturer: Vishay-Dale; AEC Category: Q200; CompType: Resistor; EIA_Code: E24; Green_Lifecycle: Active; Green_REACh: Passed; Power_W: 0.125; SizeArea_mm2: 2.5; SizeX_mm: 2; SizeY_mm: 1.25; SizeZ_mm: 1.45; TempCo: 200 ppm/C; Tolerance_%: 5; U/M: EA; Value2: 0.125W; Value_Code: 22R0; VDC_V: 150; AEC Qualified: ?; Component Kind: Standard; ComponentLink1Description: Datasheet link; ComponentLink1URL: http://www.vishay.com/docs/20035/dcrcwe3.pdf; Green_EU RoHS: Passed with Exemption; Green_EU RoHS-2: Passed; LatestRevisionDate: 2017-06-23; Library Name: Resistor_0805.IntLib; MountType: SMT; PackageReference: 0805; PartNumber: CRCW080522R0JNEA; Published: 2008-06-26; Res_ohm: 22; RoHS: Y; Signal Integrity: Resistor; Simulation: Resistor; Supplier 1: Digi-Key; Supplier 2: Newark; Supplier 3: Mouser; Supplier Part Number 1: 541-22ACT-ND; Supplier Part Number 2: 59M6875; Supplier Part Number 3: 71-CRCW080522R0JNEA; SymbolVersion: 2009-05-29; Technology: Thick Film; Value1: 5%; ValueDisplayed: 22; Publisher: Texas Instruments
R38-R41 R44 = CRCW0805100RFKEA: RES, 100, 1%, 0.125 W, 0805; Manufacturer: Vishay-Dale; AEC Category: Q200; CompType: Resistor; EIA_Code: E96; Green_Lifecycle: Active; Green_REACh: Passed; Power_W: 0.125; SizeArea_mm2: 2.5; SizeX_mm: 2; SizeY_mm: 1.25; SizeZ_mm: 1.45; TempCo: 100 ppm/C; Tolerance_%: 1; U/M: EA; Value2: 0.125W; Value_Code: 100R; VDC_V: 150; AEC Qualified: ?; Component Kind: Standard; ComponentLink1Description: Datasheet link; ComponentLink1URL: http://www.vishay.com/docs/20035/dcrcwe3.pdf; Green_EU RoHS: Passed with Exemption; Green_EU RoHS-2: Passed; LatestRevisionDate: 2017-06-23; Library Name: Resistor_0805.IntLib; MountType: SMT; PackageReference: 0805; PartNumber: CRCW0805100RFKEA; Published: 2008-06-26; Res_ohm: 100; RoHS: Y; Signal Integrity: Resistor; Simulation: Resistor; Supplier 1: Digi-Key; Supplier 2: Newark; Supplier 3: Mouser; Supplier Part Number 1: 541-100CCT-ND; Supplier Part Number 2: 52K9809; Supplier Part Number 3: 71-CRCW0805-100-E3; SymbolVersion: 2009-05-29; Technology: Thick Film; Value1: 1%; ValueDisplayed: 100; Publisher: Texas Instruments
R42 = RG2012P-224-B-T5: RES, 220 k, 0.1%, 0.125 W, 0805; Manufacturer: Susumu Co Ltd; AEC Category: Q200; CompType: Resistor; Power_W: 0.125; Res_ohm: 220000; SizeArea_mm2: 2.5; SizeX_mm: 2; SizeY_mm: 1.25; SizeZ_mm: 1.45; TempCo: 25 ppm/C; Tolerance_%: 0.1; U/M: EA; Value2: 0.125W; VDC_V: 100; AEC Qualified: ?; Component Kind: Standard; ComponentLink1Description: Datasheet link; ComponentLink1URL: http://www.susumu.co.jp/english/pdf/products-j01-01.pdf; LatestRevisionDate: 2017-06-23; Library Name: Resistor_0805.IntLib; MountType: SMT; PackageReference: 0805; PartNumber: RG2012P-224-B-T5; Published: 2008-06-26; RoHS: Y; Signal Integrity: Resistor; Simulation: Resistor; Supplier 1: Digi-Key; Supplier 2: Mouser; Supplier Part Number 1: RG20P220KBCT-ND; Supplier Part Number 2: 754-RG2012P-224-B-T5; SymbolVersion: 2009-05-29; Technology: Thin Film; Value1: 0.1%; Value_Code: 220k; ValueDisplayed: 220k; Publisher: Texas Instruments
R43 = ERJ-6ENF1502V: RES, 15.0 k, 1%, 0.125 W, 0805; Manufacturer: Panasonic; AEC Category: Q200; CompType: Resistor; EIA_Code: E96; Power_W: 0.125; Res_ohm: 15000; SizeArea_mm2: 2.5; SizeX_mm: 2; SizeY_mm: 1.25; SizeZ_mm: 1.45; TempCo: 100 ppm/C; Tolerance_%: 1; U/M: EA; Value2: 0.125W; Value_Code: 15k0; AEC Qualified: ?; Component Kind: Standard; ComponentLink1Description: Datasheet link; ComponentLink1URL: http://www.panasonic.com/industrial/components/pdf/AOA0000CE2.pdf; LatestRevisionDate: 2017-06-23; Library Name: Resistor_0805.IntLib; MountType: SMT; PackageReference: 0805; PartNumber: ERJ-6ENF1502V; Published: 2008-06-26; RoHS: Y; Signal Integrity: Resistor; Simulation: Resistor; Supplier 1: Digi-Key; Supplier 2: Mouser; Supplier 3: Farnell; Supplier Part Number 1: P15.0KCCT-ND; Supplier Part Number 2: 667-ERJ-6ENF1502V; Supplier Part Number 3: 1748380; SymbolVersion: 2009-05-29; Technology: Thick Film; Value1: 1%; ValueDisplayed: 15.0k; Publisher: Texas Instruments
RT1 = 103AT-2: Thermistor NTC, 10.0k ohm, 1%, Disc, 5x8.4 mm; Manufacturer: SEMITEC Corporation; Power_W: 0.01; TaMax_C: 110; TaMin_C: -50; Tolerance_%: 1; U/M: EA; Component Kind: Standard; ComponentLink1Description: Datasheet link; ComponentLink1URL: http://www.semitec.co.jp/english2/products/pdf/AT_Thermistor.pdf; CompType: ThermistorNTC; LatestRevisionDate: 2017-03-31; Library Name: ThermistorNTC.IntLib; MountType: TH; PackageReference: Disc, 5x8.4 mm; PartNumber: 103AT-2; Published: 2009-09-22; RoHS: Y; Series: AT; Supplier 1: Mouser; Supplier Part Number 1: 954-103AT-2; SymbolVersion: 2008-11-25; Publisher: Texas Instruments
U1 = STM32F405RGT6: ARM Cortex-M4 32-bit MCU+FPU, 210 DMIPS, 1024 kB Flash, 192 kB Internal RAM, 51 I/Os, 64-pin LQFP, -40 to 85 degC, Tray; Manufacturer: STMicroelectronics; D/A Converter: 2x12-bit; FLASH Size (Prog) (kB): 1024; I/Os (High Current): 51; Internal RAM Size (kB): 192; Operating Frequency(F) (Processor speed) (MHz): 168; Supply Voltage(Vcc) max (V): 3.6; Supply Voltage(Vcc) min (V): 1.8; Component Kind: Standard; ComponentLink1Description: Manufacturer URL; ComponentLink1URL: http://www.st.com/web/en/home.html; ComponentLink2Description: Datasheet; ComponentLink2URL: http://www.st.com/st-web-ui/static/active/en/resource/technical/document/datasheet/DM00037051.pdf; ComponentLink3Description: Package Specification; ComponentLink3URL: http://www.st.com/st-web-ui/static/active/en/resource/technical/document/datasheet/DM00037051.pdf; Core: ARM Cortex-M4; DatasheetVersion: Rev. 4; Library Name: STMicroelectronics STM32 F4.IntLib; Mounting Technology: Surface Mount; PackageDescription: 64-Pin Low Profile Quad Flat Package, 10 x 10 mm Body, 0.5 mm Pitch; PackageReference: LQFP64; PackageVersion: Rev. 4, 06/2013; Packing: Tray; PartNumber: STM32F405RGT6; Supplier 1: Digi-Key; Supplier 2: Farnell; Supplier 3: Newark; Supplier 4: Mouser; Supplier Part Number 1: 497-11767-ND; Supplier Part Number 2: 2064363; Supplier Part Number 3: 73T2329; Supplier Part Number 4: 511-STM32F405RGT6
U2 = CMP-0076113-2: 3-Phase Brushless Motor Pre-Driver with Dual Current Sense Amps and Buck Converter (PWM Ctrl w/ SPI), DCA0056A (TSSOP-56); Alternate Manufacturer: Texas Instruments; CompType: IC; SizeArea_mm2: 113.4; SizeAreaWB_mm2: 161.6; SizeX_mm: 8.1; SizeY_mm: 14.0; SizeZ_mm: 1.2; U/M: EA; Component Kind: Standard; ComponentLink1Description: Datasheet Link; ComponentLink1URL: http://www.ti.com/lit/ds/symlink/drv8301.pdf; LatestRevisionDate: 2017-06-09; Library Name: Altium Vault - TI; Manufacturer: Texas Instruments; MountType: SMT; PackageDescription: TSSOP-56; PackageReference: DCA0056A; RoHS: Y; Publisher: Texas Instruments
U3 = TLV1117LV33DCYR: Single Output LDO, 1 A, Fixed 3.3 V Output, 2 to 5.5 V Input, 4-pin SOT-223 (DCY), -40 to 125 degC, Green (RoHS & no Sb/Br); Alternate Manufacturer: Texas Instruments; Alternate PartNumber: Equivalent; Accuracy(%): 2; Fixed Output Options(V): 3.3; Iout(Max)(A): 1; Iq(Typ)(mA): 0.05; SubFamily: Single Channel LDO; Vdo(Typ)(mV): 1200; Vin(Max)(V): 5.5; Code_JEDEC: TO-261; Component Kind: Standard; ComponentLink1Description: Manufacturer URL; ComponentLink1URL: http://www.ti.com/; ComponentLink2Description: Datasheet; ComponentLink2URL: http://www.ti.com/general/docs/lit/getliterature.tsp?genericPartNumber=TLV1117LV33&fileType=pdf; ComponentLink3Description: Package Specification; ComponentLink3URL: http://www.ti.com/litv/pdf/mpds094a; DatasheetVersion: SBVS160A; Eco-Plan: Green (RoHS & no Sb/Br); Library Name: TI Power Management Linear Regulator Single Channel LDO (400-7500mA).IntLib; Manufacturer: Texas Instruments; MountType: SMT; PackageDescription: 4-Pin Plastic Small Outline, Body 3.5 x 6.5 mm, Pitch 2.3 mm; PackageReference: DCY0004A; PackageVersion: 4202506/B; PartNumber: TLV1117LV33DCYR; Supplier 1: Digi-Key; Supplier 2: Digi-Key; Supplier 3: Digi-Key; Supplier 4: Newark; Supplier 5: Mouser; Supplier Part Number 1: 296-28778-2-ND; Supplier Part Number 2: 296-28778-1-ND; Supplier Part Number 3: 296-28778-6-ND; Supplier Part Number 4: 48T4644; Supplier Part Number 5: 595-TLV1117LV33DCYR; Vin(Min)(V): 2; Vout Adj(Max)(V): 3.3; Vout Adj(Min)(V): 3.3
U4 = CMP-0075990-1: Automotive Fault Protected CAN Transceiver With Flexible Data-Rate, D0008A (SOIC-8); Alternate Manufacturer: Texas Instruments; Alternate PartNumber: TCAN1042HDQ1; CompType: IC; SizeArea_mm2: 30.38; SizeAreaWB_mm2: 56.58; SizeX_mm: 4.9; SizeY_mm: 6.2; SizeZ_mm: 1.75; U/M: EA; Component Kind: Standard; ComponentLink1Description: Datasheet Link; ComponentLink1URL: http://www.ti.com/lit/ds/symlink/tcan1042-q1.pdf; LatestRevisionDate: 2017-06-09; Library Name: Altium Vault - TI; Manufacturer: Texas Instruments; MountType: SMT; PackageDescription: SOIC-8; PackageReference: D0008A; PartNumber: TCAN1042HDRQ1; RoHS: Y; Publisher: Texas Instruments
Y1 = ABM3B-8.000MHZ-10-1-U-T: Crystal, 8 MHz, 10 pF, SMD; Manufacturer: Abracon Corporation; Frequency Stability: ±10ppm; SymbolCreator: a0412235; TaMax_C: 60; TaMin_C: -10; U/M: EA; Component Kind: Standard; ComponentLink1Description: Datasheet Link; ComponentLink1URL: http://www.abracon.com/Resonators/abm3b.pdf; CompType: Crystal; LatestRevisionDate: 2017-06-23; Library Name: Oscillators.IntLib; MountType: SMT; PackageReference: Crystal, 3.2x1.1x5.x mm; PartNumber: ABM3B-8.000MHZ-10-1-U-T; RoHS: Y; Supplier 1: Digi-Key; Supplier 2: Newark; Supplier 3: Mouser; Supplier Part Number 1: 535-9721-1-ND; Supplier Part Number 2: 40Y2012; Supplier Part Number 3: 815-ABM3B-8.0-10-1UT; SymbolVersion: 2016-1-26; Publisher: Texas Instruments
